# Altium schematic sheet SENSORS.SchDoc
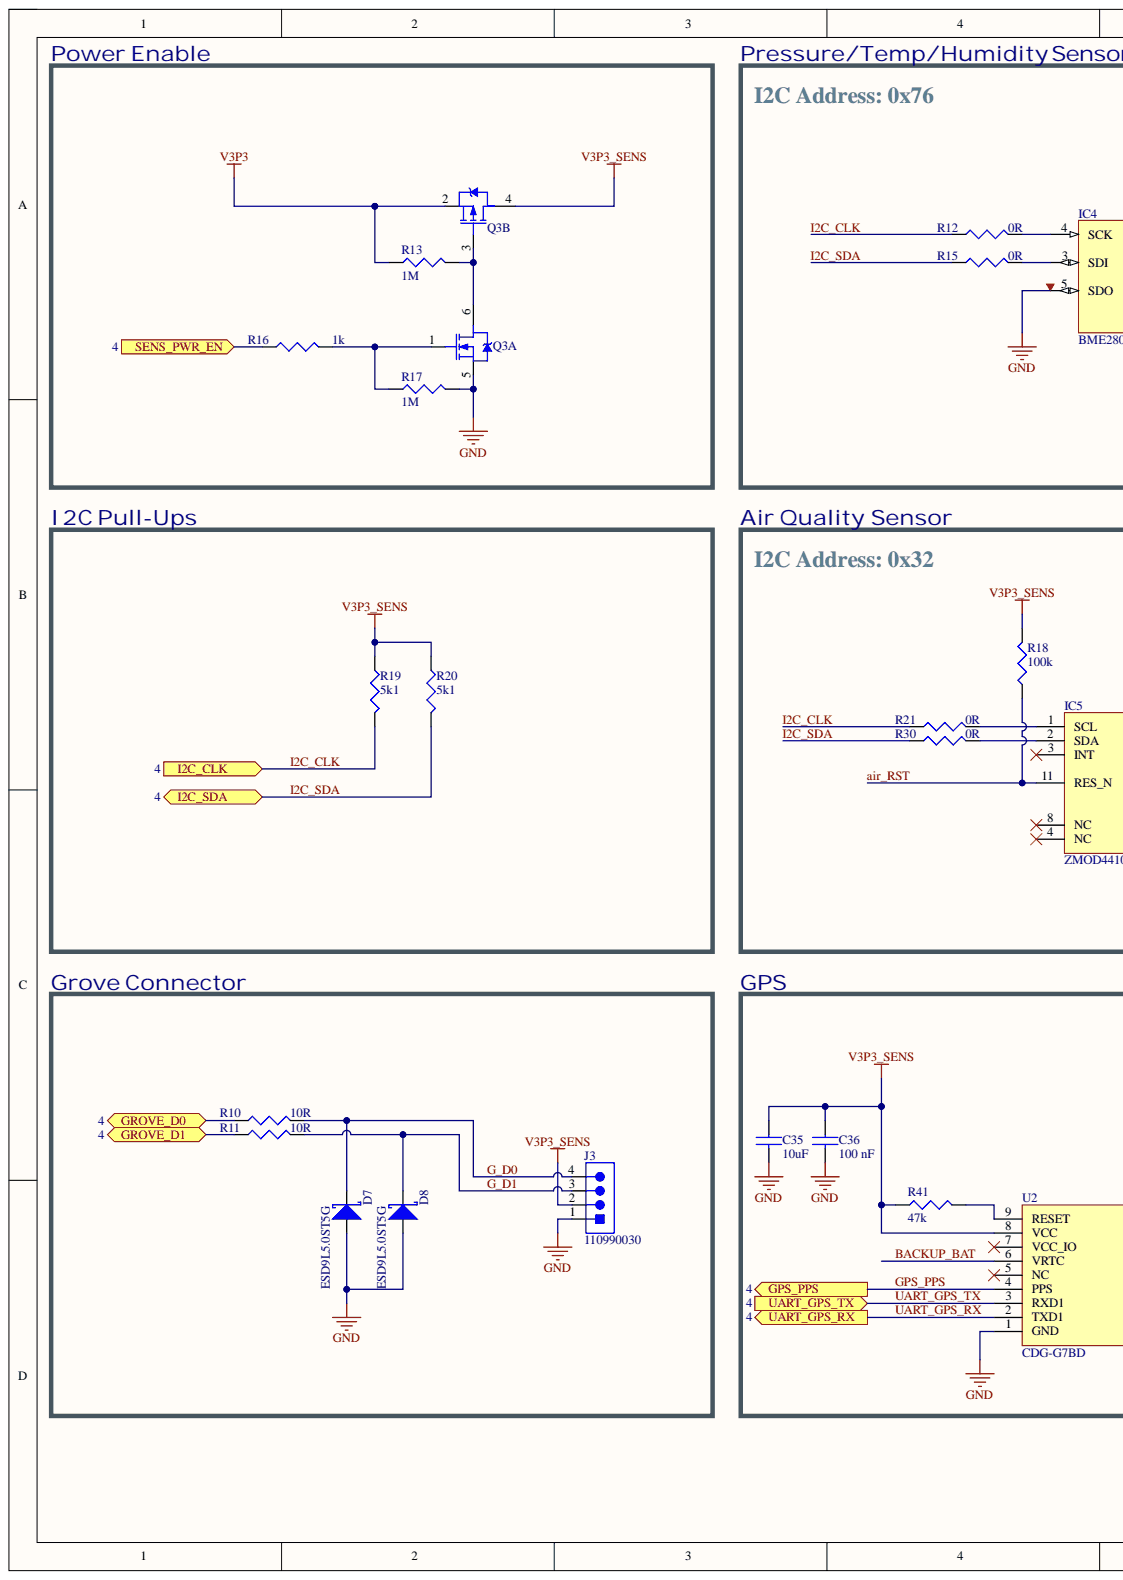
[diagram p0: SENSORS.SchDoc, left]
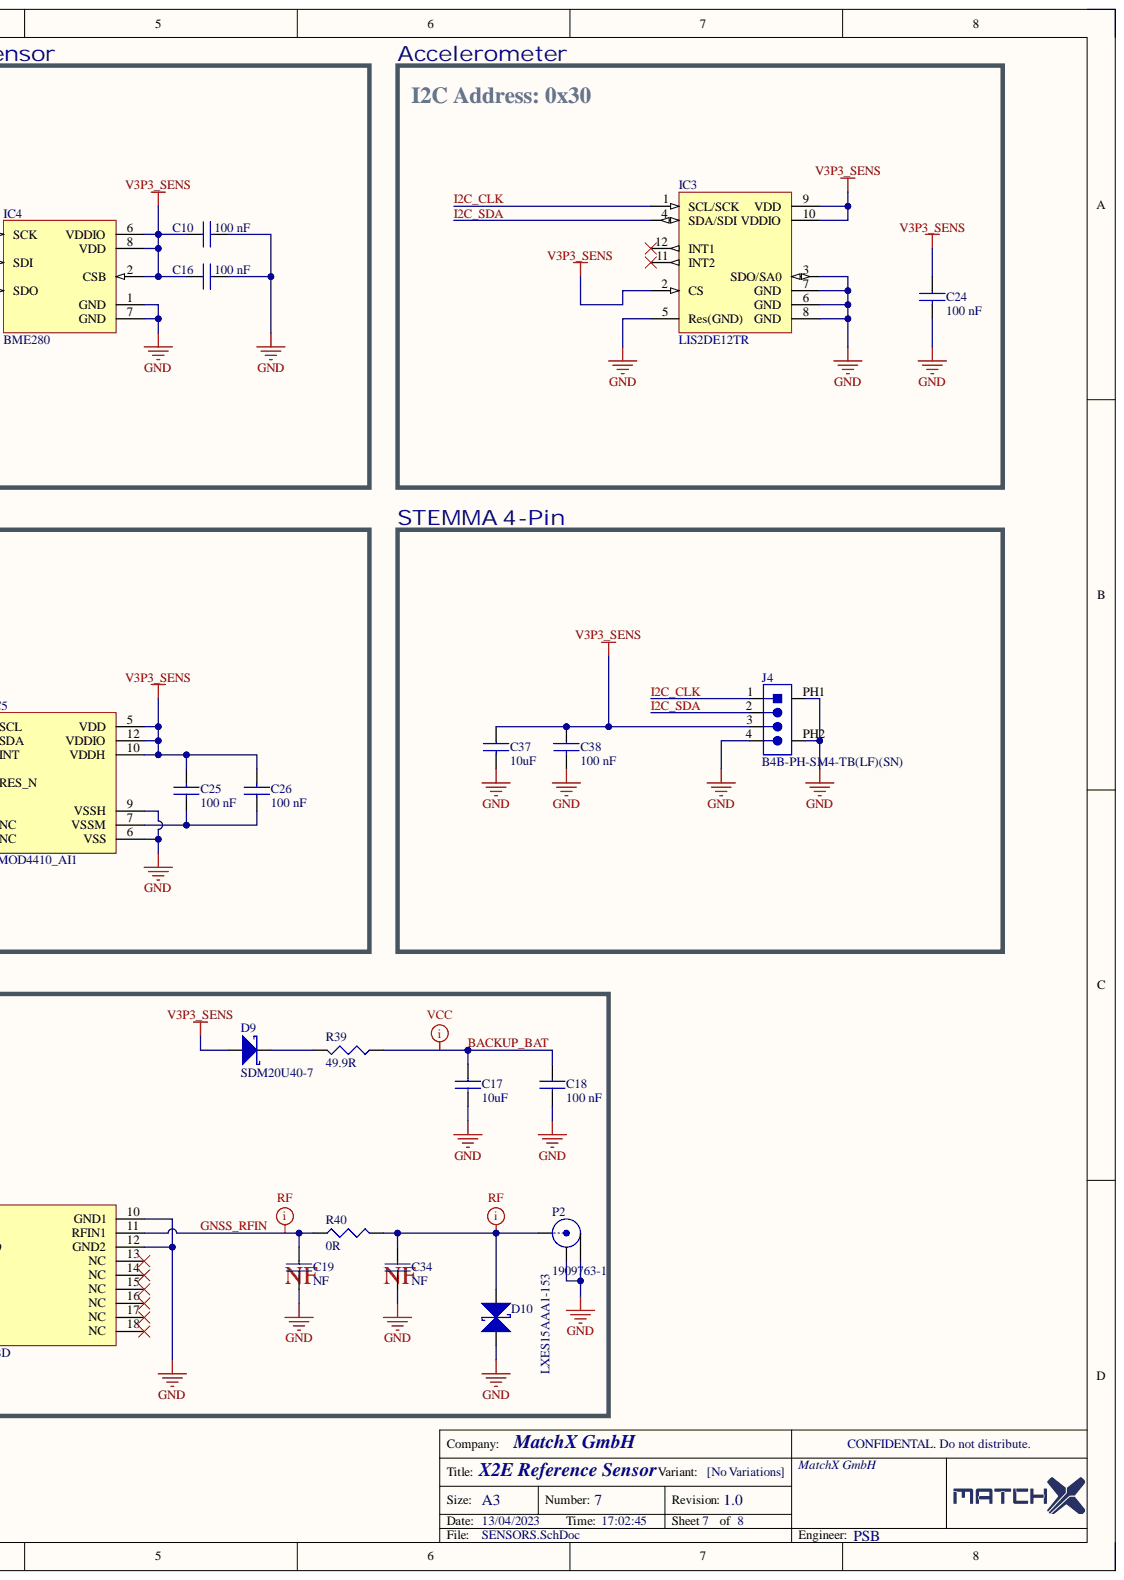
[diagram p1: SENSORS.SchDoc, right]

## Parts
C10  100 nF  [C0402]
C16  100 nF  [C0402]
C17  10uF  [C0805]
C18  100 nF  [C0402]
C19  NF  [C0402]
C24  100 nF  [C0402]
C25  100 nF  [C0402]
C26  100 nF  [C0402]
C34  NF  [C0402]
C35  10uF  [C0805]
C36  100 nF  [C0402]
C37  10uF  [C0805]
C38  100 nF  [C0402]
D7  ESD9L5.0ST5G  [SOD-923]
D8  ESD9L5.0ST5G  [SOD-923]
D9  SDM20U40-7  [SOD-523]
D10  LXES15AAA1-153  [T0402]
IC3  LIS2DE12TR  [LGA12C50P4X4_200X200X100]
IC4  BME280  [LGA65P250X250-8]
IC5  ZMOD4410_AI1  [ZMOD4xxx_AI1_Standard]
J3  110990030  [CON-NS-TECH_1125S-4P]
J4  B4B-PH-SM4-TB(LF)(SN)  [CON_JST_B4B-PH-SM4-TB(LF)(SN)]
P2  1909763-1  [CON_U.FL]
Q3  DMC2700UDM-7  [SOT23-6]  (value not drawn)  (parts A,B)
R10  10R  [R0402]
R11  10R  [R0402]
R12  0R  [R0402]
R13  1M  [R0402]
R15  0R  [R0402]
R16  1k  [R0603]
R17  1M  [R0402]
R18  100k  [R0402]
R19  5k1  [R0603]
R20  5k1  [R0603]
R21  0R  [R0402]
R30  0R  [R0402]
R39  49.9R  [R0402]
R40  0R  .Checked SCH: RF  [R0402]
R41  47k  [R0402]
U2  CDG-G7BD  [UBLOX_Max-7Q]

## Ports
GPS_PPS, GROVE_D0, GROVE_D1, I2C_CLK, I2C_SDA, SENS_PWR_EN, UART_GPS_RX, UART_GPS_TX

## Nets
GND: C10.2 C16.2 C17.2 C18.2 C19.2 C24.2 C34.2 C35.2 C36.2 C37.2 C38.2 D7.2 D8.2 D10.1 IC3.3(SDO/SA0) IC3.5(Res(GND)) IC3.6(GND) IC3.7(GND) IC3.8(GND) IC4.1(GND) IC4.5(SDO) IC4.7(GND) IC5.6(VSS) IC5.9(VSSH) J3.1 J4.4 J4.PH1 J4.PH2 P2.2 P2.3 Q3.5 R17.1 U2.1(GND) U2.10(GND1) U2.12(GND2)
V3P3: Q3.2 R13.2
V3P3_SENS: C10.1 C16.1 C24.1 C25.2 C26.2 C35.1 C36.1 C37.1 C38.1 D9.2 IC3.2(CS) IC3.9(VDD) IC3.10(VDDIO) IC4.2(CSB) IC4.6(VDDIO) IC4.8(VDD) IC5.5(VDD) IC5.10(VDDH) IC5.12(VDDIO) J3.2 J4.3 Q3.4 R18.2 R19.2 R20.2 R41.1 U2.8(VCC)
air_RST: IC5.11(RES_N) R18.1
BACKUP_BAT: C17.1 C18.1 R39.1 U2.6(VRTC)
G_D0: D7.1 J3.4 R10.1
G_D1: D8.1 J3.3 R11.1
GNSS_RFIN: C19.1 R40.1 U2.11(RFIN1)
GPS_PPS: U2.4(PPS) ; port GPS_PPS
GROVE_D0: R10.2 ; port GROVE_D0
GROVE_D1: R11.2 ; port GROVE_D1
I2C_CLK: IC3.1(SCL/SCK) J4.1 R12.1 R19.1 R21.1 ; port I2C_CLK
I2C_SDA: IC3.4(SDA/SDI) J4.2 R15.1 R20.1 R30.1 ; port I2C_SDA
SENS_PWR_EN: R16.2 ; port SENS_PWR_EN
UART_GPS_RX: U2.2(TXD1) ; port UART_GPS_RX
UART_GPS_TX: U2.3(RXD1) ; port UART_GPS_TX
NetC25_1: C25.1 C26.1 IC5.7(VSSM)
NetC34_1: C34.1 D10.2 P2.1 R40.2
NetD9_1: D9.1 R39.2
NetIC4_3: IC4.3(SDI) R15.2
NetIC4_4: IC4.4(SCK) R12.2
NetIC5_1: IC5.1(SCL) R21.2
NetIC5_2: IC5.2(SDA) R30.2
NetQ3_1: Q3.1 R16.1 R17.2
NetQ3_3: Q3.3 Q3.6 R13.1
NetR41_2: R41.2 U2.9(RESET)

Not connected: IC3 11(INT2) 12(INT1); IC5 3(INT) 4(NC) 8(NC); U2 5(NC) 7(VCC_IO) 13(NC) 14(NC) 15(NC) 16(NC) 17(NC) 18(NC)

## Library data of the parts
C10 C16 C25 C26 = C0402-100nF: CAP, CERM, 100 nF, 16V, +/- 10%, X7R, 0402; CompType: Capacitor; Category: Capacitors; Component Kind: Standard; MountType: SMT; Operating Temperature: -55°C ~ 125°C; Package / Case: 0402; RoHS: RoHS Compliant; Technology: Ceramic; Temperature Coefficient: X7R; Tolerance: 10%; Value: 100 nF; Voltage - Rated: 16 V; Library Name: psblib.SCHLIB
C17 C35 C37 = C0805-10uF_25V: CAP, CERM, 10uF, 25V, +/- 20%, X5R, 0805; CompType: Capacitor; Category: Capacitors; Component Kind: Standard; MountType: SMT; Operating Temperature: -55°C ~ 85°C; Package / Case: 0805 (2012 Metric); RoHS: RoHS Compliant; Technology: Ceramic; Temperature Coefficient: X5R; Tolerance: 20%; Value: 10uF; Voltage - Rated: 25V; Library Name: psblib.SCHLIB
C18 C24 C36 C38 = C0402-100nF_50V: CAP, CERM, 100 nF, 50V, +/- 10%, X7R, 0402; CompType: Capacitor; Category: Capacitors; Component Kind: Standard; MountType: SMT; Operating Temperature: -55°C ~ 125°C; Package / Case: 0402; RoHS: RoHS Compliant; Technology: Ceramic; Temperature Coefficient: X7R; Tolerance: 10%; Value: 100 nF; Voltage - Rated: 50 V; Library Name: psblib.SCHLIB
C19 C34 = C0402-1.8pF: CAP, CERM, 1.8pF, 50V, +/- 0.1pF, C0G/NP0, 0402; CompType: Capacitor; Category: Capacitors; Component Kind: Standard; MountType: SMT; Operating Temperature: -55°C ~ 125°C; Package / Case: 0402; RoHS: RoHS Compliant; Technology: Ceramic; Temperature Coefficient: C0G/NP0; Tolerance: +/- 0.1pF; Value: NF; Voltage - Rated: 50V; Library Name: psblib.SCHLIB
D7 D8 = TVSUni_ESD9L5.0ST5G: TVS DIODE 5V 9.8V SOD923; Manufacturer: ON Semiconductor; Breakdown Voltage: 5.4V; Capacitance: 0.9pF; Direction: Unidirectional; Element Configuration: Single; ESD Protection: Yes; Leakage Current: 1uA; Max Surge Current: 1A; Number of Channels: 1; Peak Pulse Power: 150mW; Power Line Protection: No; Reverse Breakdown Voltage: 5.8V; Test Current: 1mA; Case/Package: SOD-923-2; Clamping Voltage: 9.8V; Component Kind: Standard; ComponentLink1Description: https://datasheet.ciiva.com/15143/getdatasheetpartid-185186-15143896.pdf?src-supplier=Verical; ComponentLink1URL: https://datasheet.ciiva.com/15143/getdatasheetpartid-185186-15143896.pdf?src-supplier=Verical; ComponentLink2Description: https://datasheet.ciiva.com/4510/esd9l-d-4510647.pdf?src-supplier=Mouser; ComponentLink2URL: https://datasheet.ciiva.com/4510/esd9l-d-4510647.pdf?src-supplier=Mouser; ComponentLink3Description: https://datasheet.ciiva.com/3304/esd9l-d-3304011.pdf?src-supplier=Avnet; ComponentLink3URL: https://datasheet.ciiva.com/3304/esd9l-d-3304011.pdf?src-supplier=Avnet; ComponentLink4Description: https://datasheet.ciiva.com/16437/esd9l-d-16437835.pdf?src-supplier=Future+Electronics; ComponentLink4URL: https://datasheet.ciiva.com/16437/esd9l-d-16437835.pdf?src-supplier=Future+Electronics; ComponentLink5Description: https://datasheet.ciiva.com/339/esd9l-d-339853.pdf?src-supplier=Digi-Key; ComponentLink5URL: https://datasheet.ciiva.com/339/esd9l-d-339853.pdf?src-supplier=Digi-Key; ComponentLink6Description: https://datasheet.ciiva.com/339/esd9l-d-339854.pdf?src-supplier=Digi-Key; ComponentLink6URL: https://datasheet.ciiva.com/339/esd9l-d-339854.pdf?src-supplier=Digi-Key; ComponentLink7Description: https://datasheet.ciiva.com/11195/esd9l-d-11195342.pdf?src-supplier=Avnet; ComponentLink7URL: https://datasheet.ciiva.com/11195/esd9l-d-11195342.pdf?src-supplier=Avnet; ComponentLink8Description: https://datasheet.ciiva.com/25958/esd9l-d-25958446.pdf?src-supplier=Avnet+Europe; ComponentLink8URL: https://datasheet.ciiva.com/25958/esd9l-d-25958446.pdf?src-supplier=Avnet+Europe; ComponentLink9Description: https://datasheet.ciiva.com/339/esd9l-d-339855.pdf?src-supplier=Digi-Key; ComponentLink9URL: https://datasheet.ciiva.com/339/esd9l-d-339855.pdf?src-supplier=Digi-Key; Composition: Zener; Contact Plating: Tin,Matte; Depth: 0.6mm; Halogen Free: Halogen Free; Height: 0.4mm; Lead Free: Lead Free; Length: 0.8mm; Manufacturer Part Number: ESD9L5.0ST5G; Max Breakdown Voltage: 5.4V; Max Operating Temperature: 150°C; Max Reverse Leakage Current: 1uA; Min Breakdown Voltage: 5.4V; Min Operating Temperature: -55°C; Number of Elements: 1; Number of Lines: 1; Number of Pins: 2; Number of Unidirectional Channels: 1; Operating Supply Voltage: 5V; Package Quantity: 1; Packaging: Tape and Reel; Peak Pulse Current: 1A; Polarity: Unidirectional; Power Dissipation: 150mW; Pricing 1: 1=0,36000, 10=0,29700, 100=0,15730, 500=0,10350, 1000=0,07038 (USD); Radiation Hardening: No; REACH SVHC: No SVHC; Reverse Standoff Voltage: 5V; RoHS: Yes; Stock 1: 56991; Supplier 1: Digi-Key; Supplier Part Number 1: ESD9L5.0ST5GOSCT-ND; Termination: SMD/SMT; Width: 0.65mm; Working Voltage: 5V; Library Name: psblib.SCHLIB
D9 = Diode_SDM20U40-7: Diode Schottky 40V 250mA (DC) Surface Mount SOD-523; Manufacturer: Diodes; Brand: Diodes Incorporated; Configuration: Single; If - Forward Current: 0.25 A; Ifsm - Forward Surge Current: 1 A; Ir - Reverse Current: 5 uA at 30 V; Pd - Power Dissipation: 150 mW; trr - Reverse Recovery time: 10 ns; Type: Schottky Barrier Diode; Unit Weight: 0.000035 oz; Vf - Forward Voltage: 0.6 V at 0.2 A; Component Kind: Standard; ComponentLink1Description: https://datasheet.ciiva.com/27052/1541976-27052427.pdf; ComponentLink1URL: https://datasheet.ciiva.com/27052/1541976-27052427.pdf; ComponentLink2Description: https://datasheet.ciiva.com/16199/ds30393-16199987.pdf; ComponentLink2URL: https://datasheet.ciiva.com/16199/ds30393-16199987.pdf; ComponentLink3Description: https://datasheet.ciiva.com/8303/ds30393-8303264.pdf; ComponentLink3URL: https://datasheet.ciiva.com/8303/ds30393-8303264.pdf; ComponentLink4Description: https://datasheet.ciiva.com/912/ds30393-912155.pdf; ComponentLink4URL: https://datasheet.ciiva.com/912/ds30393-912155.pdf; ComponentLink5Description: https://datasheet.ciiva.com/8301/ds30393-8301179.pdf; ComponentLink5URL: https://datasheet.ciiva.com/8301/ds30393-8301179.pdf; Factory Pack Quantity: 3000; Height: 0.65 mm; Length: 1.3 mm; Manufacturer Part Number: SDM20U40-7; Maximum Operating Temperature: + 125 C; Minimum Operating Temperature: - 65 C; Mounting Style: SMD/SMT; Operating Temperature Range: - 65 C to + 125 C; Package / Case: SOD-523; Packaging: Reel; Pricing 1: 1=0.37, 10=0.304, 100=0.1615, 500=0.1062, 1000=0.0722 (USD); Product: Schottky Diodes; Product Category: Schottky Diodes & Rectifiers; RoHS: True; Series: SDM20U40; Stock 1: 50745; Supplier 1: Digikey; Supplier Part Number 1: SDM20U40-7DIDKR-ND; Technology: Si; Termination Style: SMD/SMT; Vrrm - Repetitive Reverse Voltage: 40 V; Width: 0.9 mm; Library Name: psblib.SCHLIB
D10 = TVSBi_LXES15AAA1-153: TVS DIODE 15 V 0402; Manufacturer: Murata; Bidirectional Channels: 1; Capacitance @ Frequency: 0.05pF @ 1MHz; Power Line Protection: No; Type: Zener; Voltage - Reverse Standoff (Typ): 4V; Applications: General Purpose; Category: Circuit Protection; Component Kind: Standard; Current - Peak Pulse (10/1000µs): -; Family: TVS - Diodes; Manufacturer Part Number: LXES15AAA1-153; Mounting Type: Surface Mount; Operating Temperature: -40°C ~ 85°C (TA); Package / Case: 0402 (1005 Metric); Packaging: Tape & Reel (TR); Power - Peak Pulse: -; Pricing 1: 1=0.19, 10=0.105, 50=0.105, 100=0.073, 1000=0.054, 10000=0.042 (USD); Series: -; Stock 1: 40283; Supplier 1: Mouser; Supplier Device Package: 1005; Supplier Part Number 1: 81-LXES15AAA1-153; Unidirectional Channels: -; Voltage - Breakdown (Min): -; Voltage - Clamping (Max) @ Ipp: -; Library Name: psblib.SCHLIB
IC3 = ST=LIS2DE12: Accelerometer X, Y, Z Axis ±2g, 4g, 8g, 16g 0.5Hz ~ 2.69kHz 12-LGA (2x2); Manufacturer: STMicroelectronics; .Checked PCB: OK M; Axis: X, Y, Z; Max Supply Voltage: 3.6V; Min Supply Voltage: 1.7V; Output Type: SPI,I2C,Digital; Bandwidth: 0.5Hz; Case/Package: LGA; Component Kind: Standard; ComponentLink1Description: http://www.farnell.com/datasheets/2028147.pdf?src-supplier=Farnell; ComponentLink1URL: http://www.farnell.com/datasheets/2028147.pdf?src-supplier=Farnell; ComponentLink2Description: https://www.mouser.com/ds/2/389/lis2de12-954977.pdf?src-supplier=Mouser; ComponentLink2URL: https://www.mouser.com/ds/2/389/lis2de12-954977.pdf?src-supplier=Mouser; ComponentLink3Description: http://www.st.com/content/ccc/resource/technical/document/datasheet/group0/72/cb/80/a7/5e/fe/46/9d/DM00153214/files/DM00153214.pdf/jcr:content/translations/en.DM00153214.pdf?src-supplier=Digi-Key; ComponentLink3URL: http://www.st.com/content/ccc/resource/technical/document/datasheet/group0/72/cb/80/a7/5e/fe/46/9d/DM00153214/files/DM00153214.pdf/jcr:content/translations/en.DM00153214.pdf?src-supplier=Digi-Key; ComponentLink4Description: http://www.st.com/st-web-ui/static/active/en/resource/technical/document/datasheet/DM00153214.pdf?src-supplier=Avnet; ComponentLink4URL: http://www.st.com/st-web-ui/static/active/en/resource/technical/document/datasheet/DM00153214.pdf?src-supplier=Avnet; ComponentLink5Description: http://www.farnell.com/datasheets/2028147.pdf?src-supplier=Element14; ComponentLink5URL: http://www.farnell.com/datasheets/2028147.pdf?src-supplier=Element14; ComponentLink6Description: http://www.st.com/st-web-ui/static/active/en/resource/technical/document/datasheet/DM00153214.pdf?src-supplier=Avnet+Asia; ComponentLink6URL: http://www.st.com/st-web-ui/static/active/en/resource/technical/document/datasheet/DM00153214.pdf?src-supplier=Avnet+Asia; Contact Plating: Gold; Lead Free: Lead Free; Manufacturer Part Number: LIS2DE12TR; Max Operating Temperature: 85°C; Min Operating Temperature: -40°C; Mount: Surface Mount; Number of Pins: 12; Packaging: Cut Tape; Pricing 1: 1=1,45000, 10=1,29800, 25=1,23200, 100=1,01200, 500=0,83600, 1000=0,66000 (USD); REACH SVHC: No SVHC; RoHS: Yes; Stock 1: 3611; Supplier 1: LCSC; Supplier Part Number 1: C155639; .Checked SCH: OK M; Library Name: psblib.SCHLIB
IC4 = BOSH=BME280: SENSOR PRESSURE HUMIDITY TEMP; Manufacturer: Bosch; Accuracy: 3%; Output Type: SPI,I2C; Response Time: 1s; Sensor Type: Temperature; Case/Package: LGA; Category: Temperature and Humidity Sensors; Component Kind: Standard; ComponentLink1Description: https://ae-bst.resource.bosch.com/media/_tech/media/datasheets/BST-BME280-DS002.pdf?src-supplier=Digi-Key; ComponentLink1URL: https://ae-bst.resource.bosch.com/media/_tech/media/datasheets/BST-BME280-DS002.pdf?src-supplier=Digi-Key; ComponentLink2Description: https://ae-bst.resource.bosch.com/media/_tech/media/product_flyer/BME280_Productflyer_BST_20170109.pdf?src-supplier=Mouser; ComponentLink2URL: https://ae-bst.resource.bosch.com/media/_tech/media/product_flyer/BME280_Productflyer_BST_20170109.pdf?src-supplier=Mouser; ComponentLink3Description: https://ae-bst.resource.bosch.com/media/_tech/media/product_flyer/BME280_Productflyer_BST_20170109.pdf?src-supplier=Arrow+Electronics; ComponentLink3URL: https://ae-bst.resource.bosch.com/media/_tech/media/product_flyer/BME280_Productflyer_BST_20170109.pdf?src-supplier=Arrow+Electronics; ComponentLink4Description: https://ae-bst.resource.bosch.com/media/_tech/media/product_flyer/BME280_Productflyer_BST_20170109.pdf?src-supplier=NAC+Semi; ComponentLink4URL: https://ae-bst.resource.bosch.com/media/_tech/media/product_flyer/BME280_Productflyer_BST_20170109.pdf?src-supplier=NAC+Semi; Lead Free: Lead Free; Manufacturer Part Number: BME280; Max Operating Temperature: 85°C; Min Operating Temperature: -40°C; Mount: Surface Mount; Number of Pins: 8; Packaging: Cut Tape; Pricing 1: 1=4,307900, 10=4,265400, 25=4,222800, 50=4,180300, 100=4,126200, 250=4,084800, 500=3,869800, 1000=3,655900, 3000=3,440800, 6000=3,226900 (USD); RoHS: No; Stock 1: 6372; Supplier 1: Arrow Electronics; Supplier Part Number 1: BME280; Library Name: psblib.SCHLIB
IC5 = Renesas=ZMOD4410_AI1: Indoor Air Quality Sensor; Component Kind: Standard; Manufacturer: Renesas; Manufacturer Part Number: ZMOD4410_AI1; Library Name: psblib.SCHLIB
J3 = CON_SeedStudio_110990030: 4 Positions Header Connector 0.079" (2.00mm) Through Hole Tin; Manufacturer: Seeed Studio; Component Kind: Standard; ComponentLink1Description: http://www.mouser.com/ds/2/744/Seeed_316010005-786598.pdf; ComponentLink1URL: http://www.mouser.com/ds/2/744/Seeed_316010005-786598.pdf; ComponentLink2Description: http://www.seeedstudio.com/document/pdf/Preface.pdf; ComponentLink2URL: http://www.seeedstudio.com/document/pdf/Preface.pdf; Manufacturer Part Number: 110990030; Stock 1: 0; Supplier 1: Digi-Key; Supplier Part Number 1: 1597-1082-ND; Library Name: psblib.SCHLIB
J4 = CON_B4B-PH-SM4-TB: CONN HEADER SMD 4POS 2MM; Manufacturer: JST; Connector Type: Male Pin; Contact Resistance: 20mR; Current Rating: 2A; Gender: Male; Insulation Resistance: 1GR; Max Voltage Rating (AC): 100V; Number of Contacts: 4; Color: Natural,White; Component Kind: Standard; ComponentLink1Description: https://datasheet.ciiva.com/8052/1699381-8052048.pdf?src-supplier=Element14; ComponentLink1URL: https://datasheet.ciiva.com/8052/1699381-8052048.pdf?src-supplier=Element14; ComponentLink2Description: https://datasheet.ciiva.com/11747/0900766b80ddea81-11747504.pdf?src-supplier=RSComponents; ComponentLink2URL: https://datasheet.ciiva.com/11747/0900766b80ddea81-11747504.pdf?src-supplier=RSComponents; ComponentLink3Description: https://datasheet.ciiva.com/6747/80567-6747409.pdf?src-supplier=Newark; ComponentLink3URL: https://datasheet.ciiva.com/6747/80567-6747409.pdf?src-supplier=Newark; ComponentLink4Description: https://datasheet.ciiva.com/727/eph-727525.pdf?src-supplier=Digi-Key; ComponentLink4URL: https://datasheet.ciiva.com/727/eph-727525.pdf?src-supplier=Digi-Key; ComponentLink5Description: https://datasheet.ciiva.com/712/eph-712582.pdf?src-supplier=Digi-Key; ComponentLink5URL: https://datasheet.ciiva.com/712/eph-712582.pdf?src-supplier=Digi-Key; ComponentLink6Description: https://datasheet.ciiva.com/712/eph-712583.pdf?src-supplier=Digi-Key; ComponentLink6URL: https://datasheet.ciiva.com/712/eph-712583.pdf?src-supplier=Digi-Key; Contact Material: Copper Alloy,Bronze,Tin,Brass; Contact Mating Length: 0.13inch; Contact Plating: Tin,Copper; Depth: 5mm; Fastening Type: Detent; Flammability Rating: UL94 V-0; Height: 9.4mm; Housing Color: Beige; Housing Material: Polyamide; Lead Free: Lead Free; Lead Pitch: 2mm; Length: 11.95mm; Manufacturer Part Number: B4B-PH-SM4-TB(LF)(SN); Max Current Rating: 2A; Max Operating Temperature: 85°C; Min Operating Temperature: -25°C; Mount: Surface Mount; Number of Poles: 4; Number of Positions: 4; Number of Rows: 1; Orientation: Straight; Packaging: Tape and Reel; Pitch: 2mm; Pricing 1: 1=0,800000, 10=0,750000, 100=0,575100, 500=0,500060 (USD); REACH SVHC: No SVHC; RoHS: Yes; Stock 1: 67893; Supplier 1: Digi-Key; Supplier Part Number 1: 455-1736-1-ND; Termination: Solder; Voltage Rating: 100V; Voltage Rating (AC): 100V; Width: 5.5mm; Working Voltage: 100V; Library Name: psblib.SCHLIB
P2 = CON_U.FL: CONN UMCC JACK STR 50OHM SMD; Manufacturer: TE Connectivity AMP Connectors; .Checked PCB: OK M; Body Finish: Gold; Body Material: Copper Alloy; Connector Style: Ultra Miniature Coaxial; Connector Type: Jack, Male Pin; Contact Termination: Solder; Dielectric Material: Liquid Crystal Polymer (LCP); Frequency - Max: 6GHz; Includes: 1 pc - 1 Connector; Insertion Loss: 0.24dB; Mating Cycles: 30; Voltage Rating: 60V; Cable Group: -; Category: Connectors, Interconnects; Center Contact Material: Copper Alloy; Center Contact Plating: Gold; Component Kind: Standard; ComponentLink1Description: http://www.te.com/commerce/DocumentDelivery/DDEController?Action=srchrtrv&DocNm=1909763&DocType=Customer+Drawing&DocLang=English; ComponentLink1URL: http://www.te.com/commerce/DocumentDelivery/DDEController?Action=srchrtrv&DocNm=1909763&DocType=Customer+Drawing&DocLang=English; Fastening Type: Snap-On; Features: -; Housing Color: Ivory; Impedance: 50 Ohm; Ingress Protection: -; Manufacturer Part Number: 1909763-1; Mounting Feature: -; Mounting Type: Surface Mount; Operating Temperature: -40°C ~ 90°C; Packaging: Cut Tape (CT); Part Status: Active; Pricing 1: 1=0.54, 10=0.508, 25=0.4572, 50=0.4066, 100=0.3896, 250=0.35576, 500=0.3388, 1000=0.27951, 2500=0.2541 (USD); RoHS: RoHS Compliant; Shield Termination: Solder; Stock 1: 68653; Supplier 1: Digi-Key; Supplier Part Number 1: A118077CT-ND; .Checked SCH: OK M; Library Name: psblib.SCHLIB
Q3 = Diodes=DMC2700UDM-7: Trans MOSFET N/P-CH 20V 1.34A/1.14A Automotive 6-Pin SOT-26 T/R; Manufacturer: Diodes; Continuous Drain Current (ID): 1.14A; Drain to Source Resistance: 1.3R; FET Type: N and P-Channel; Gate to Source Voltage (Vgs): 6V; Input Capacitance: 60.67pF; Max Power Dissipation: 1.12W; Number of Channels: 2; Polarity: N-CHANNEL,P-CHANNEL; Rds On Max: 400mR; Turn-Off Delay Time: 28.4ns; Turn-On Delay Time: 5.1ns; Case/Package: SOT-26; Category: MOSFETs; Component Kind: Standard; ComponentLink1Description: https://datasheet.ciiva.com/928/dmc2700udm-928784.pdf?src-supplier=Digi-Key; ComponentLink1URL: https://datasheet.ciiva.com/928/dmc2700udm-928784.pdf?src-supplier=Digi-Key; ComponentLink2Description: https://datasheet.ciiva.com/13051/dmc2700udm-73200-13051769.pdf?src-supplier=Mouser; ComponentLink2URL: https://datasheet.ciiva.com/13051/dmc2700udm-73200-13051769.pdf?src-supplier=Mouser; Drain to Source Breakdown Voltage: 20V; Drain to Source Voltage (Vdss): 20V; Height: 1.3mm; Lead Free: Lead Free; Length: 3.1mm; Manufacturer Part Number: DMC2700UDM-7; Max Operating Temperature: 150°C; Min Operating Temperature: -55°C; Mount: Surface Mount; Number of Elements: 2; Number of Pins: 6; Package Quantity: 3000; Packaging: Tape and Reel; Power Dissipation: 1.12W; Pricing 1: 1=0.44000, 10=0.32800, 100=0.18590, 500=0.12308, 1000=0.09437 (USD); Radiation Hardening: No; REACH SVHC: No SVHC; RoHS: Yes; RoHS Compliant: Yes; Series: -; Stock 1: 122900; Supplier 1: Digi-Key; Supplier Part Number 1: DMC2700UDM-7CT-ND; Width: 1.7mm; Library Name: psblib.SCHLIB
R10 R11 = R0402-10R: RES, 10R, 1%, 1/16 W, 0402; .Checked PCB: OK RF; .Checked SCH: RF; Power (Watts): 0.063W, 1/16W; Category: Resistors; Component Kind: Standard; Composition: Thick Film; Features: -; Height: 0.016" (0.40mm); Number of Terminations: 2; Package / Case: 0402; RoHS: RoHS Compliant; Size / Dimension: 0.039" L x 0.020" W (1.00mm x 0.50mm); Tolerance: ±1%; Value: 10R; Library Name: psblib.SCHLIB
R12 R15 R21 R30 = R0402-0R: RES, 0R, 1%, 1/16 W, 0402; .Checked PCB: OK RF; .Checked SCH: RF; Power (Watts): 0.063W, 1/16W; Category: Resistors; Component Kind: Standard; Composition: Thick Film; Features: -; Height: 0.016" (0.40mm); Number of Terminations: 2; Package / Case: 0402; RoHS: RoHS Compliant; Size / Dimension: 0.039" L x 0.020" W (1.00mm x 0.50mm); Tolerance: ±1%; Value: 0R; Library Name: psblib.SCHLIB
R13 R17 = R0402-1M: RES, 1M, 1%, 1/16 W, 0402; .Checked PCB: OK RF; .Checked SCH: RF; Power (Watts): 0.063W, 1/16W; Category: Resistors; Component Kind: Standard; Composition: Thick Film; Features: -; Height: 0.016" (0.40mm); Number of Terminations: 2; Package / Case: 0402; RoHS: RoHS Compliant; Size / Dimension: 0.039" L x 0.020" W (1.00mm x 0.50mm); Tolerance: ±1%; Value: 1M; Library Name: psblib.SCHLIB
R16 = R0603-1k: RES, 1k, 1%, 0.063 W, 0603; .Checked PCB: OK RF; .Checked SCH: RF; Power (Watts): 0.063W, 1/16W; Category: Resistors; Component Kind: Standard; Composition: Thick Film; Features: -; Height: 0.016" (0.40mm); Number of Terminations: 2; Package / Case: 0603 [1608 Metric]; RoHS: RoHS Compliant; Size / Dimension: 0.063" L x 0.031" W (1.60mm x 0.80mm); Tolerance: ±1%; Value: 1k; Library Name: psblib.SCHLIB
R18 = R0402-100k: RES, 100k, 1%, 1/16 W, 0402; .Checked PCB: OK RF; .Checked SCH: RF; Power (Watts): 0.063W, 1/16W; Category: Resistors; Component Kind: Standard; Composition: Thick Film; Features: -; Height: 0.016" (0.40mm); Number of Terminations: 2; Package / Case: 0402; RoHS: RoHS Compliant; Size / Dimension: 0.039" L x 0.020" W (1.00mm x 0.50mm); Tolerance: ±1%; Value: 100k; Library Name: psblib.SCHLIB
R19 R20 = R0603-5k1: RES, 5k1, 1%, 0.063 W, 0603; .Checked PCB: OK RF; .Checked SCH: RF; Power (Watts): 0.063W, 1/16W; Category: Resistors; Component Kind: Standard; Composition: Thick Film; Features: -; Height: 0.016" (0.40mm); Number of Terminations: 2; Package / Case: 0603 [1608 Metric]; RoHS: RoHS Compliant; Size / Dimension: 0.063" L x 0.031" W (1.60mm x 0.80mm); Tolerance: ±1%; Value: 5k1; Library Name: psblib.SCHLIB
R39 = R0402-49.9R: RES, 49.9R, 1%, 1/16 W, 0402; .Checked PCB: OK RF; .Checked SCH: RF; Power (Watts): 0.063W, 1/16W; Category: Resistors; Component Kind: Standard; Composition: Thick Film; Features: -; Height: 0.016" (0.40mm); Number of Terminations: 2; Package / Case: 0402; RoHS: RoHS Compliant; Size / Dimension: 0.039" L x 0.020" W (1.00mm x 0.50mm); Tolerance: ±1%; Value: 49.9R; Library Name: psblib.SCHLIB
R40 = R0402-0R: RES, 0R, 1%, 1/16 W, 0402; .Checked PCB: OK RF; Power (Watts): 0.063W, 1/16W; Category: Resistors; Component Kind: Standard; Composition: Thick Film; Features: -; Height: 0.016" (0.40mm); Number of Terminations: 2; Package / Case: 0402; RoHS: RoHS Compliant; Size / Dimension: 0.039" L x 0.020" W (1.00mm x 0.50mm); Tolerance: ±1%; Value: 0R; Library Name: psblib.SCHLIB
R41 = R0402-47k: RES, 47k, 1%, 1/16 W, 0402; .Checked PCB: OK RF; .Checked SCH: RF; Power (Watts): 0.063W, 1/16W; Category: Resistors; Component Kind: Standard; Composition: Thick Film; Features: -; Height: 0.016" (0.40mm); Number of Terminations: 2; Package / Case: 0402; RoHS: RoHS Compliant; Size / Dimension: 0.039" L x 0.020" W (1.00mm x 0.50mm); Tolerance: ±1%; Value: 47k; Library Name: psblib.SCHLIB
U2 = CDG-G7BD: GPS, GLONASS, QZSS and SBAS Module; Manufacturer: CDTECH; Component Kind: Standard; Manufacturer Part Number: CDG-G7BD; Value: CDG-G7BD; Library Name: psblib.SCHLIB
